# Altium schematic sheet led3.SchDoc
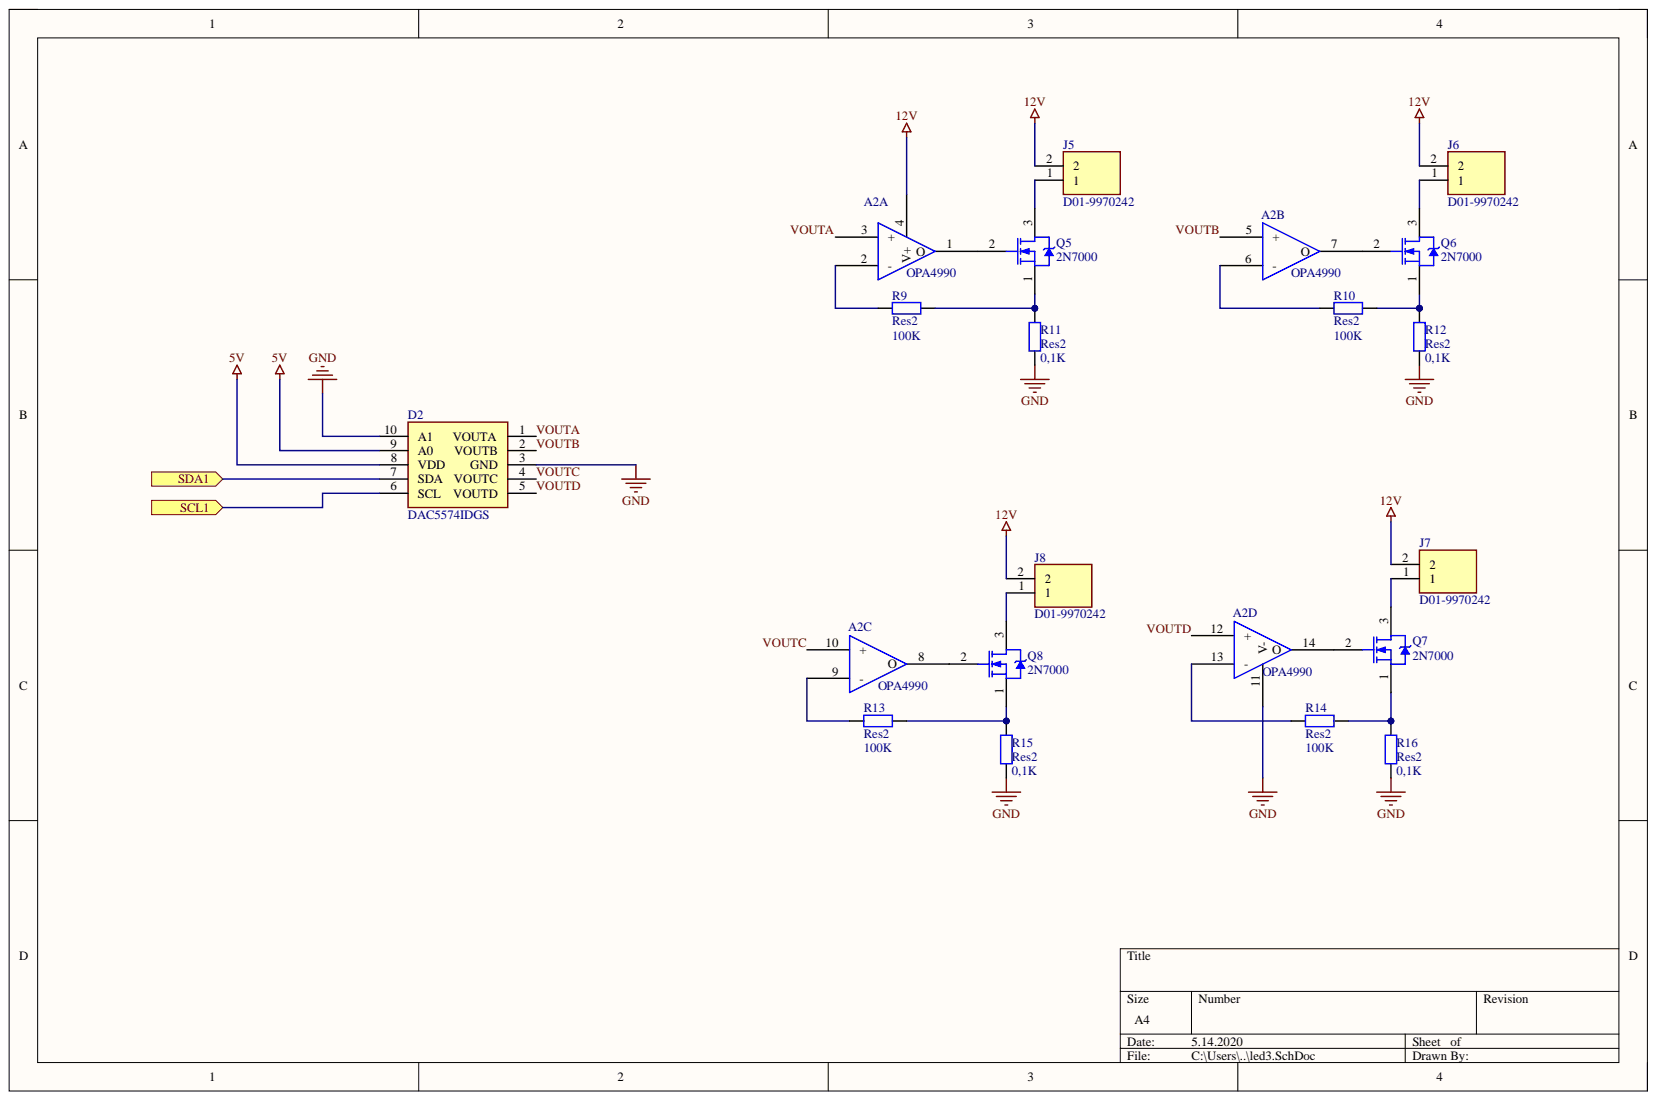
[diagram p0: led3.SchDoc]

## Parts
A2  OPA4990  [SOIC127P600X175-14N]  (parts A,B,C,D)
D2  DAC5574IDGS  [SOP50P490X110-10N]
J5  D01-9970242  [HDR1X2]
J6  D01-9970242  [HDR1X2]
J7  D01-9970242  [HDR1X2]
J8  D01-9970242  [HDR1X2]
Q5  2N7000  [ONSC-TO-92-3-29-11]
Q6  2N7000  [ONSC-TO-92-3-29-11]
Q7  2N7000  [ONSC-TO-92-3-29-11]
Q8  2N7000  [ONSC-TO-92-3-29-11]
R9  Res2  value 100K  [6-0805_L]
R10  Res2  value 100K  [6-0805_L]
R11  Res2  value 0,1K  [C1210]
R12  Res2  value 0,1K  [C1210]
R13  Res2  value 100K  [6-0805_L]
R14  Res2  value 100K  [6-0805_L]
R15  Res2  value 0,1K  [C1210]
R16  Res2  value 0,1K  [C1210]

## Ports
SCL1, SDA1

## Nets
5V: D2.8(VDD) D2.9(A0)
12V: A2.4(V+) J5.2 J6.2 J7.2 J8.2
GND: A2.11(V-) D2.3(GND) D2.10(A1) R11.1 R12.1 R15.1 R16.1
SCL1: D2.6(SCL) ; port SCL1
SDA1: D2.7(SDA) ; port SDA1
VOUTA_3: A2.3(+) D2.1(VOUTA)  [also labelled VOUTA]
VOUTB_3: A2.5(+) D2.2(VOUTB)  [also labelled VOUTB]
VOUTC_3: A2.10(+) D2.4(VOUTC)  [also labelled VOUTC]
VOUTD_3: A2.12(+) D2.5(VOUTD)  [also labelled VOUTD]
NetA2_1: A2.1(O) Q5.2
NetA2_2: A2.2(-) R9.1
NetA2_6: A2.6(-) R10.1
NetA2_7: A2.7(O) Q6.2
NetA2_8: A2.8(O) Q8.2
NetA2_9: A2.9(-) R13.1
NetA2_13: A2.13(-) R14.1
NetA2_14: A2.14(O) Q7.2
NetJ5_1: J5.1 Q5.3
NetJ6_1: J6.1 Q6.3
NetJ7_1: J7.1 Q7.3
NetJ8_1: J8.1 Q8.3
NetQ5_1: Q5.1 R9.2 R11.2
NetQ6_1: Q6.1 R10.2 R12.2
NetQ7_1: Q7.1 R14.2 R16.2
NetQ8_1: Q8.1 R13.2 R15.2

## Library data of the parts
D2 = DAC5574IDGS: Integrated Circuit; Manufacturer_Name: Texas Instruments; Component Kind: Standard; Datasheet Link: http://www.ti.com/lit/gpn/dac5574; Height: 1.1mm; Manufacturer_Part_Number: DAC5574IDGS; Mouser Part Number: 595-DAC5574IDGS; Mouser Price/Stock: https://www.mouser.com/Search/Refine.aspx?Keyword=595-DAC5574IDGS; RS Part Number: 6621533P; RS Price/Stock: http://uk.rs-online.com/web/p/products/6621533P
J5-J8 = D01-9970242: Connector; Manufacturer_Name: HARWIN; Component Kind: Standard; Datasheet Link: https://componentsearchengine.com/Datasheets/1/D01-9970242.pdf; Height: 4.25mm; Library Name: SamacSys2.SchLib; Manufacturer_Part_Number: D01-9970242; Mouser Part Number: 855-D01-9970242; Mouser Price/Stock: https://www.mouser.com/Search/Refine.aspx?Keyword=855-D01-9970242
Q5-Q8 = CMP-1058-00936-1: Small Signal MOSFET, 200 mA, 60 V, N-Channel, 3-Pin TO-92, Bulk Box; Manufacturer: On Semiconductor; Channel Polarity: N Channel; Ciss Typ (pF): 60; Configuration: Single; Coss Typ (pF): 25; Crss Typ (pF): 5; ID Max (A): 0.2; PD Max (W): 0.35; VGS Max (V): 20; VGS(th) Max (V): 3; Component Kind: Standard; ComponentLink1Description: Manufacturer URL; ComponentLink1URL: http://www.onsemi.com/; ComponentLink2Description: Datasheet; ComponentLink2URL: http://www.onsemi.com/pub_link/Collateral/2N7000-D.PDF; ComponentLink3Description: Package Specification; ComponentLink3URL: http://www.onsemi.cn/pub_link/Collateral/2N6426-D.PDF; DatasheetVersion: Rev. 8; Library Name: Components - 24.04.20 - 07.58.SchLib; Mounting Technology: Through Hole; Package Type: TO-92; PackageDescription: 3-Pin Transistor Outline, Body 4.825 x 3.685 mm, Pitch 1.27 mm; PackageReference: TO-92-3-29-11_AM_SL; PackageVersion: Rev. AM; Packing: Bulk Box; PartNumber: 2N7000; V(BR)DSS Min (V): 60
R9-R16 = Res2: Resistor; Component Kind: Standard; LatestRevisionDate: 17-Jul-2002; LatestRevisionNote: Re-released for DXP Platform.; Library Name: Miscellaneous Devices.IntLib; PackageDescription: Axial Device, Thru-Hole; 2 Leads; 0.4 in Pin Spacing; PackageReference: AXIAL-0.4; Published: 8-Jun-2000; Signal Integrity: Res; Simulation: RESISTOR; Publisher: Altium Limited
A2 = OPA4990: Component Kind: Standard; Library Name: Kalibracija.SchLib
